# Altium schematic sheet 07_IV_sensor.SchDoc(07_IV_sensor)
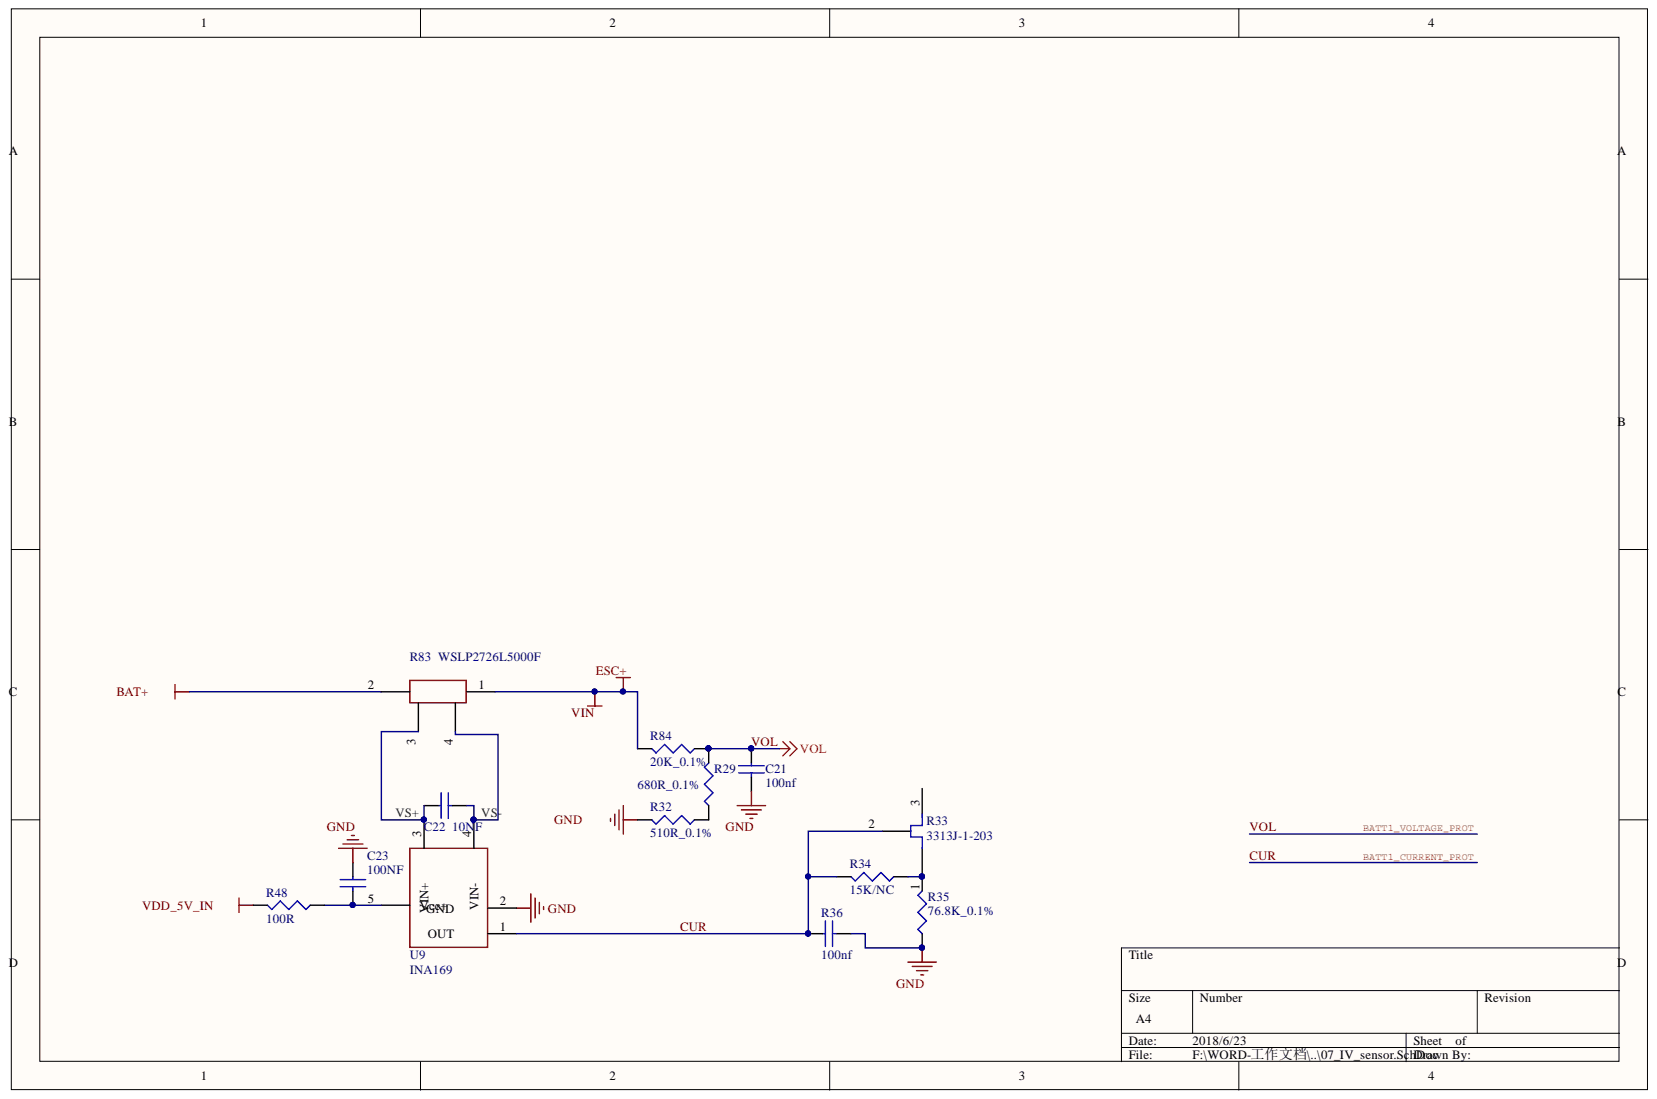
[diagram p0: 07_IV_sensor.SchDoc]

## Parts
C21  100nf  [0402c]
C22  10NF  [0402cap]
C23  100NF  [0402cap]
R29  680R_0.1%  [0603r]
R32  510R_0.1%  [0603R]
R33  3313J-1-203  [3313J-1]
R34  15K/NC  [0402R]
R35  76.8K_0.1%  [0603R]
R36  100nf  [0402c]
R48  100R  [R0402]
R83  WSLP2726L5000F  [WSLP2726]
R84  20K_0.1%  [0603r]
U9  INA169  [SOT23-5]

## Nets
BAT+: R83.2
ESC+: R83.1 R84.2
GND: C21.2 C23.1 R32.2 R35.1 R36.2 U9.2
VDD_5V_IN: R48.2
BATT1_CURRENT_PROT: R33.2 R34.2 R36.1 U9.1
BATT1_VOLTAGE_PROT: C21.1 R29.2 R84.1
VS+: C22.1 R83.3 U9.3
VS-: C22.2 R83.4 U9.4
NetC23_2: C23.2 R48.1 U9.5(Vcc+)
NetR29_1: R29.1 R32.1
NetR33_1: R33.1 R34.1 R35.2

Not connected: R33 3

## Library data of the parts
C21 C23 R36 = C1005X5R1H104K: CAP CER .10UF 50V X5R 10% 0402; Manufacturer: TDK Corporation; .Checked PCB: used; Capacitance: 0.1礔; Tolerance: ?0%; .Checked SCH: used; Applications: General Purpose; Category: Capacitors; Component Kind: Standard; ComponentLink1Description: http://www.tdk.co.jp/tefe02/e412_c.pdf; ComponentLink1URL: http://www.tdk.co.jp/tefe02/e412_c.pdf; Features: -; Height - Seated (Max): -; Lead Spacing: -; Lead Style: -; Manufacturer Part Number: C1005X5R1H104K; Mounting Type: Surface Mount, MLCC; Operating Temperature: -55癈 ~ 85癈; Package / Case: 0402 (1005 Metric); Packaging: Cut Tape (CT); Price 1k [$]: 0.02340; Pricing 1: 1=0.27, 10=0.18, 100=0.072, 250=0.05176, 500=0.0369, 1000=0.027 (USD); Ratings: -; RoHS: RoHS Compliant; Size / Dimension: 0.039" L x 0.020" W (1.00mm x 0.50mm); Stock 1: 106926; Supplier: Digikey; Supplier 1: Digi-Key; Supplier Part Number: 445-5942-1-ND; Supplier Part Number 1: 445-5942-1-ND; Temperature Coefficient: X5R; Thickness (Max): 0.022" (0.55mm); Value: 100nF; Voltage - Rated: 50V; Library Name: Integrated_Library_RF_FEB_2012.IntLib
C22 = C1005X5R1H104K: CAP CER .10UF 50V X5R 10% 0402; Manufacturer: TDK Corporation; .Checked PCB: used; Capacitance: 0.1礔; Tolerance: ?0%; Value: 100nF; .Checked SCH: used; Applications: General Purpose; Category: Capacitors; Component Kind: Standard; ComponentLink1Description: http://www.tdk.co.jp/tefe02/e412_c.pdf; ComponentLink1URL: http://www.tdk.co.jp/tefe02/e412_c.pdf; Features: -; Height - Seated (Max): -; Lead Spacing: -; Lead Style: -; Manufacturer Part Number: C1005X5R1H104K; Mounting Type: Surface Mount, MLCC; Operating Temperature: -55癈 ~ 85癈; Package / Case: 0402 (1005 Metric); Packaging: Cut Tape (CT); Price 1k [$]: 0.02340; Pricing 1: 1=0.27, 10=0.18, 100=0.072, 250=0.05176, 500=0.0369, 1000=0.027 (USD); Ratings: -; RoHS: RoHS Compliant; Size / Dimension: 0.039" L x 0.020" W (1.00mm x 0.50mm); Stock 1: 106926; Supplier: Digikey; Supplier 1: Digi-Key; Supplier Part Number: 445-5942-1-ND; Supplier Part Number 1: 445-5942-1-ND; Temperature Coefficient: X5R; Thickness (Max): 0.022" (0.55mm); Voltage - Rated: 50V; Library Name: Integrated_Library_RF_FEB_2012.IntLib
R29 R32 = RMCF0402FT4K99: Component Kind: Standard; Library Name: Integrated_Library_RF_FEB_2012.IntLib
R34 R35 = RMCF0402FT4K99: RES TF 4.99K OHM 1% 1/16W 0402; Component Kind: Standard; Library Name: Integrated_Library_RF_FEB_2012.IntLib
R48 = RC0402FR-0749R9L: Component Kind: Standard; Library Name: Integrated_Library_RF_FEB_2012.IntLib
R83 = WSLP2726: Component Kind: Standard; Library Name: CUAV.SchLib
R84 = RMCF0402FT4K99: RES TF 4.99K OHM 1% 1/16W 0402; Manufacturer: Stackpole Electronics Inc; .Checked PCB: used; Power (Watts): 0.063W, 1/16W; Temperature Coefficient: ?00ppm/癈; Tolerance: ?%; Value: 4k99; .Checked SCH: used; Category: Resistors; Component Kind: Standard; ComponentLink1Description: http://www.seielect.com/Catalog/SEI-RMCF.pdf; ComponentLink1URL: http://www.seielect.com/Catalog/SEI-RMCF.pdf; Composition: Thick Film; Features: -; Height: 0.016" (0.40mm); Manufacturer Part Number: RMCF0402FT4K99; Number of Terminations: 2; Package / Case: 0402 (1005 Metric); Packaging: Cut Tape (CT); Price 1k [$]: 0.00384; Pricing 1: 1=0.04, 10=0.025, 50=0.0138, 100=0.0105, 250=0.0078, 500=0.00576, 1000=0.00384 (USD); Resistance (Ohms): 4.99K; RoHS: RoHS Compliant; Size / Dimension: 0.039" L x 0.020" W (1.00mm x 0.50mm); Stock 1: 48509; Supplier: Digikey; Supplier 1: Digi-Key; Supplier Part Number: RMCF0402FT4K99CT-ND; Supplier Part Number 1: RMCF0402FT4K99CT-ND; Library Name: Integrated_Library_RF_FEB_2012.IntLib
R33 = 3313J-1-203: Component Kind: Standard; Library Name: CUAV.SchLib
U9 = INA169: Component Kind: Standard; Library Name: CUAV.SchLib
